# Altium schematic sheet [11] - FPGA BANKS 14, 15 and 16.SchDoc("[11] - FPGA BANKS 14, 15 and 16")
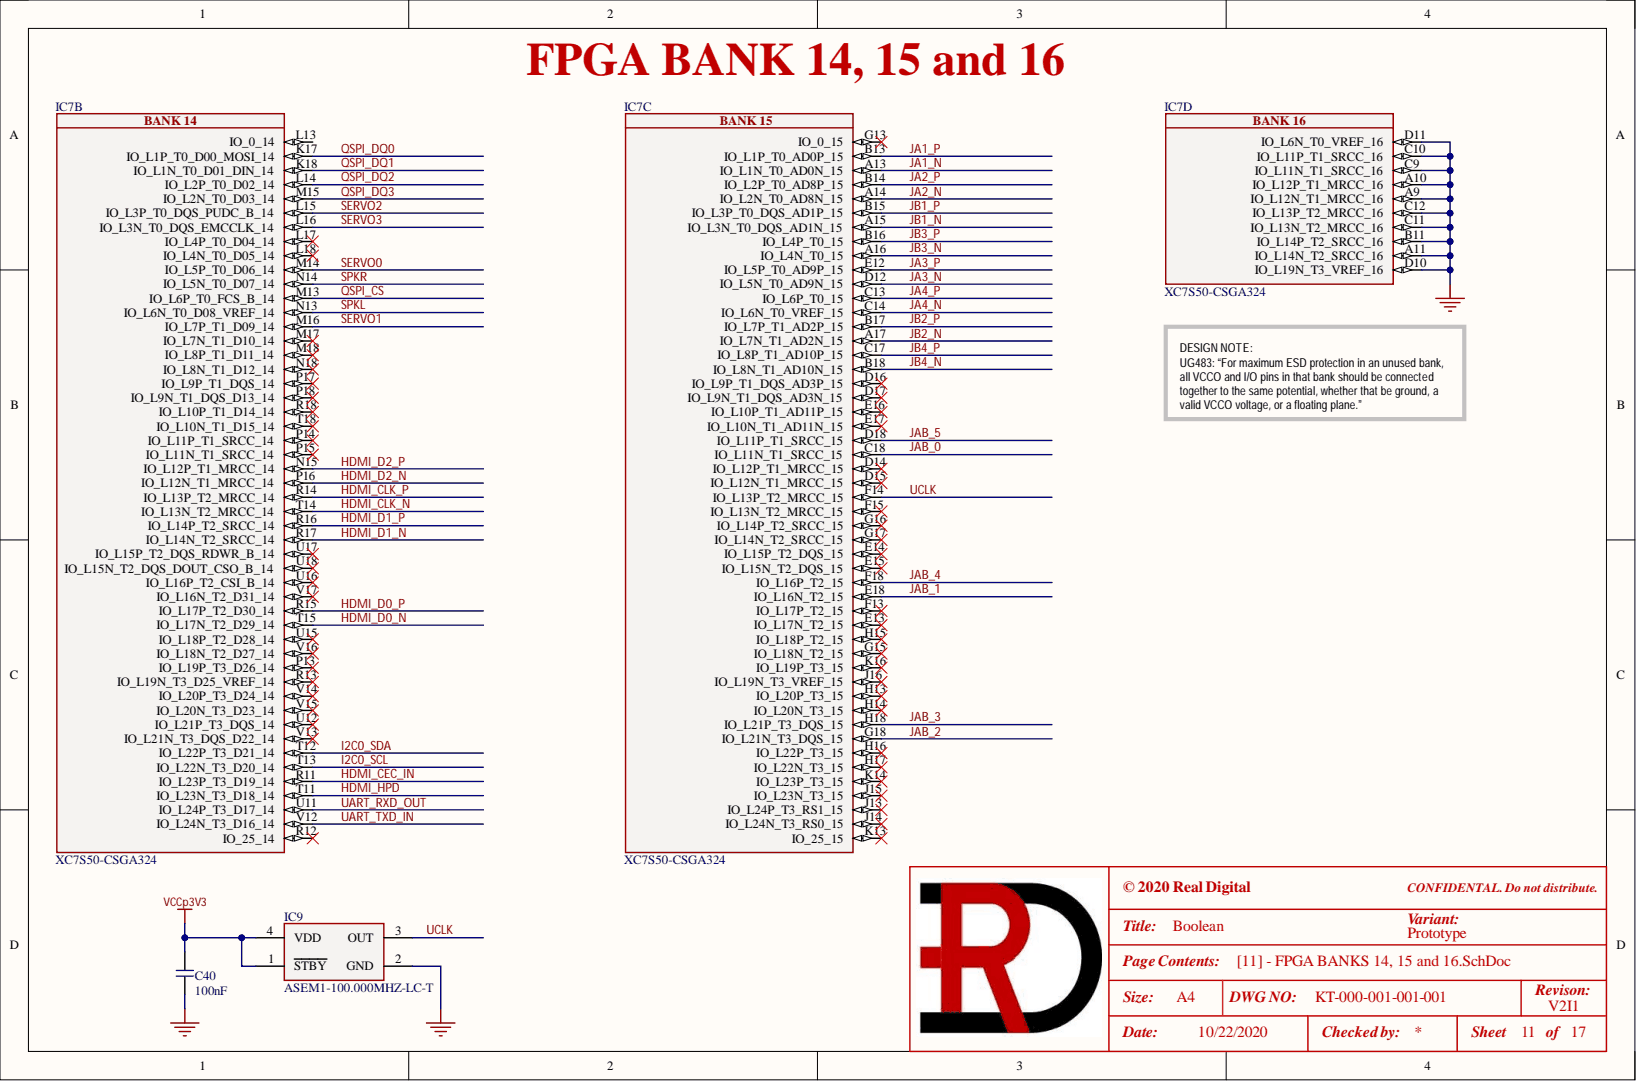
[diagram p0: [11] - FPGA BANKS 14, 15 and 16.SchDoc]

## Parts
C40  100nF  [C0402]
IC7  XC7S50-CSGA324  [CSG324]  (parts B,C,D)
IC9  ASEM1-100.000MHZ-LC-T  [DSC3.2x2.5-4]

## Nets
GND: C40.1 IC7.A9(IO_L12N_T1_MRCC_16) IC7.A10(IO_L12P_T1_MRCC_16) IC7.A11(IO_L14N_T2_SRCC_16) IC7.B11(IO_L14P_T2_SRCC_16) IC7.C9(IO_L11N_T1_SRCC_16) IC7.C10(IO_L11P_T1_SRCC_16) IC7.C11(IO_L13N_T2_MRCC_16) IC7.C12(IO_L13P_T2_MRCC_16) IC7.D10(IO_L19N_T3_VREF_16) IC7.D11(IO_L6N_T0_VREF_16) IC9.2(GND)
VCCp3V3: C40.2 IC9.1(STBY) IC9.4(VDD)
HDMI_CEC_IN: IC7.R11(IO_L23P_T3_D19_14)
HDMI_CLK_N: IC7.T14(IO_L13N_T2_MRCC_14)
HDMI_CLK_P: IC7.R14(IO_L13P_T2_MRCC_14)
HDMI_D0_N: IC7.T15(IO_L17N_T2_D29_14)
HDMI_D0_P: IC7.R15(IO_L17P_T2_D30_14)
HDMI_D1_N: IC7.R17(IO_L14N_T2_SRCC_14)
HDMI_D1_P: IC7.R16(IO_L14P_T2_SRCC_14)
HDMI_D2_N: IC7.P16(IO_L12N_T1_MRCC_14)
HDMI_D2_P: IC7.N15(IO_L12P_T1_MRCC_14)
HDMI_HPD: IC7.T11(IO_L23N_T3_D18_14)
I2C0_SCL: IC7.T13(IO_L22N_T3_D20_14)
I2C0_SDA: IC7.T12(IO_L22P_T3_D21_14)
JA1_N: IC7.A13(IO_L1N_T0_AD0N_15)
JA1_P: IC7.B13(IO_L1P_T0_AD0P_15)
JA2_N: IC7.A14(IO_L2N_T0_AD8N_15)
JA2_P: IC7.B14(IO_L2P_T0_AD8P_15)
JA3_N: IC7.D12(IO_L5N_T0_AD9N_15)
JA3_P: IC7.E12(IO_L5P_T0_AD9P_15)
JA4_N: IC7.C14(IO_L6N_T0_VREF_15)
JA4_P: IC7.C13(IO_L6P_T0_15)
JAB_0: IC7.C18(IO_L11N_T1_SRCC_15)
JAB_1: IC7.E18(IO_L16N_T2_15)
JAB_2: IC7.G18(IO_L21N_T3_DQS_15)
JAB_3: IC7.H18(IO_L21P_T3_DQS_15)
JAB_4: IC7.F18(IO_L16P_T2_15)
JAB_5: IC7.D18(IO_L11P_T1_SRCC_15)
JB1_N: IC7.A15(IO_L3N_T0_DQS_AD1N_15)
JB1_P: IC7.B15(IO_L3P_T0_DQS_AD1P_15)
JB2_N: IC7.A17(IO_L7N_T1_AD2N_15)
JB2_P: IC7.B17(IO_L7P_T1_AD2P_15)
JB3_N: IC7.A16(IO_L4N_T0_15)
JB3_P: IC7.B16(IO_L4P_T0_15)
JB4_N: IC7.B18(IO_L8N_T1_AD10N_15)
JB4_P: IC7.C17(IO_L8P_T1_AD10P_15)
QSPI_CS: IC7.M13(IO_L6P_T0_FCS_B_14)
QSPI_DQ0: IC7.K17(IO_L1P_T0_D00_MOSI_14)
QSPI_DQ1: IC7.K18(IO_L1N_T0_D01_DIN_14)
QSPI_DQ2: IC7.L14(IO_L2P_T0_D02_14)
QSPI_DQ3: IC7.M15(IO_L2N_T0_D03_14)
SERVO0: IC7.M14(IO_L5P_T0_D06_14)
SERVO1: IC7.M16(IO_L7P_T1_D09_14)
SERVO2: IC7.L15(IO_L3P_T0_DQS_PUDC_B_14)
SERVO3: IC7.L16(IO_L3N_T0_DQS_EMCCLK_14)
SPKL: IC7.N13(IO_L6N_T0_D08_VREF_14)
SPKR: IC7.N14(IO_L5N_T0_D07_14)
UART_RXD_OUT: IC7.U11(IO_L24P_T3_D17_14)
UART_TXD_IN: IC7.V12(IO_L24N_T3_D16_14)
UCLK: IC7.F14(IO_L13P_T2_MRCC_15) IC9.3(OUT)

Not connected: IC7 D14(IO_L12P_T1_MRCC_15) D15(IO_L12N_T1_MRCC_15) D16(IO_L9P_T1_DQS_AD3P_15) D17(IO_L9N_T1_DQS_AD3N_15) E13(IO_L17N_T2_15) E14(IO_L15P_T2_DQS_15) E15(IO_L15N_T2_DQS_15) E16(IO_L10P_T1_AD11P_15) E17(IO_L10N_T1_AD11N_15) F13(IO_L17P_T2_15) F15(IO_L13N_T2_MRCC_15) G13(IO_0_15) G15(IO_L18N_T2_15) G16(IO_L14P_T2_SRCC_15) G17(IO_L14N_T2_SRCC_15) H13(IO_L20P_T3_15) H14(IO_L20N_T3_15) H15(IO_L18P_T2_15) H16(IO_L22P_T3_15) H17(IO_L22N_T3_15) J13(IO_L24P_T3_RS1_15) J14(IO_L24N_T3_RS0_15) J15(IO_L23N_T3_15) J16(IO_L19N_T3_VREF_15) K13(IO_25_15) K14(IO_L23P_T3_15) K16(IO_L19P_T3_15) L13(IO_0_14) L17(IO_L4P_T0_D04_14) L18(IO_L4N_T0_D05_14) M17(IO_L7N_T1_D10_14) M18(IO_L8P_T1_D11_14) N18(IO_L8N_T1_D12_14) P13(IO_L19P_T3_D26_14) P14(IO_L11P_T1_SRCC_14) P15(IO_L11N_T1_SRCC_14) P17(IO_L9P_T1_DQS_14) P18(IO_L9N_T1_DQS_D13_14) R12(IO_25_14) R13(IO_L19N_T3_D25_VREF_14) R18(IO_L10P_T1_D14_14) T18(IO_L10N_T1_D15_14) U12(IO_L21P_T3_DQS_14) U15(IO_L18P_T2_D28_14) U16(IO_L16P_T2_CSI_B_14) U17(IO_L15P_T2_DQS_RDWR_B_14) U18(IO_L15N_T2_DQS_DOUT_CSO_B_14) V13(IO_L21N_T3_DQS_D22_14) V14(IO_L20P_T3_D24_14) V15(IO_L20N_T3_D23_14) V16(IO_L18N_T2_D27_14) V17(IO_L16N_T2_D31_14)

## Library data of the parts
C40 = GRM155R71E104KE14J: CAP CER 0.1uF 25V X7R 0402; Manufacturer 1: Murata Electronics; .Checked_PCB: AC; .Creator: RV; C P/N: RD-111-0006; LoadStatus: Load; Tolerance: 10%; Category: Capacitors; Component Kind: Standard; Library Name: KT-RealDigital.IntLib; Manufacturer 1 Part Number: GRM155R71E104KE14J; Temperature Coefficient: X7R; Voltage - Rated: 25V; .Checked_SCH: AC
IC7 = XC7S50-CSGA324: FPGA 210 I/O 324CSBGA; Manufacturer 1: Xilinx; .Creator: RV; C P/N: RD-126-0003-CD; LoadStatus: Load; Category: IC; Component Kind: Standard; Library Name: KT-RealDigital.IntLib; Manufacturer 1 Part Number: XC7S50-CSGA324
IC9 = ASEM1-100.000MHZ-LC-T: MEMS OSC XO 100.0000MHZ CMOS SMD; Manufacturer 1: Abracon LLC; Manufacturer 2 Part Number: SMA-100000-3BL4T1; .Checked_PCB: AC; .Creator: RV; C P/N: 126-1260; LoadStatus: Load; Category: Oscillators; Component Kind: Standard; Library Name: KT-RealDigital.IntLib; Manufacturer 1 Part Number: ASEM1-100.000MHZ-LC-T; Manufacturer 2: AKER TECHNOLOGY CO., LTD.; .Checked_SCH: AC
